# Altium schematic sheet AFE_mezzanine.SchDoc
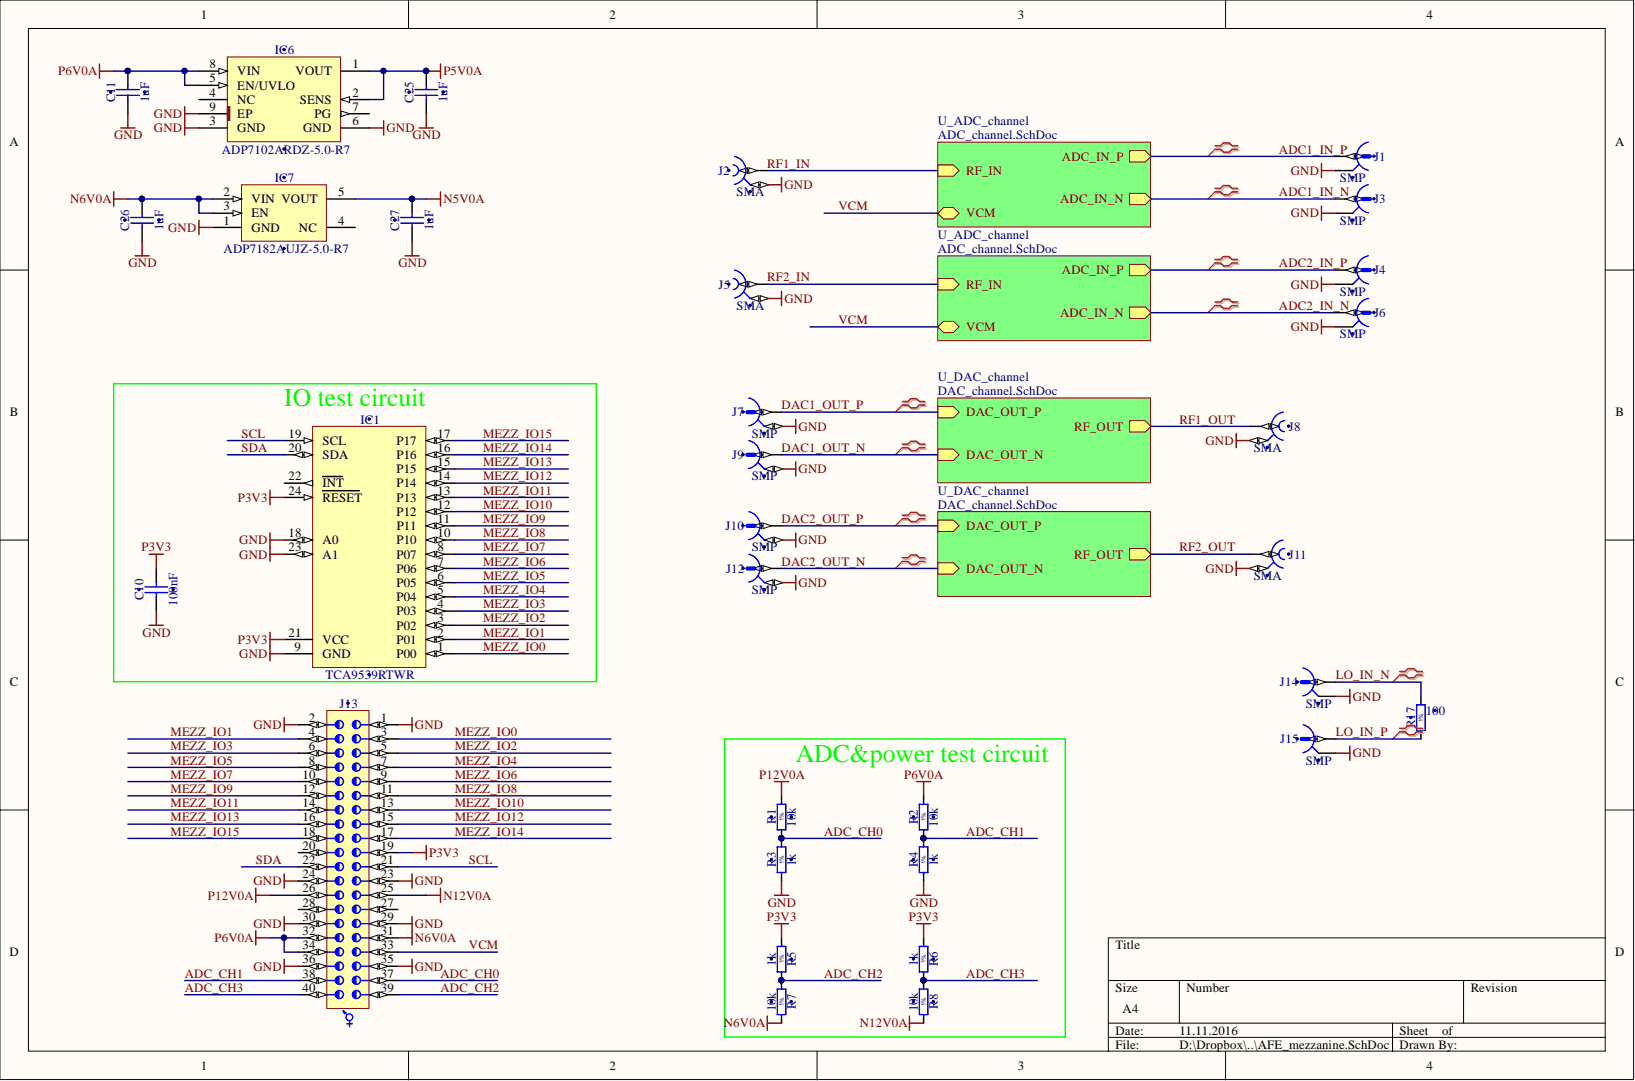
[diagram p0: AFE_mezzanine.SchDoc]

## Parts
C10  100nF  [CAPC1005X55N]
C11  1uF  [CAPC2012X140N]
C25  1uF  [CAPC2012X140N]
C26  1uF  [CAPC2012X140N]
C27  1uF  [CAPC2012X140N]
IC1  TCA9539RTWR  [QFN50P400X400X80-25N-S255]
IC6  ADP7102ARDZ-5.0-R7  [SOIC127P600X175-9N-R241X309]
IC7  ADP7182AUJZ-5.0-R7  [SOT95P280X90-5N]
J1  SMP  [AMPHENOL_SMP-MSLD-PCT-10]
J2  SMA  [Telegartner_J01151A0921]
J3  SMP  [AMPHENOL_SMP-MSLD-PCT-10]
J4  SMP  [AMPHENOL_SMP-MSLD-PCT-10]
J5  SMA  [Telegartner_J01151A0921]
J6  SMP  [AMPHENOL_SMP-MSLD-PCT-10]
J7  SMP  [MOLEX_85305-0232]
J8  SMA  [TELEGARTNER_J01151A0451]
J9  SMP  [MOLEX_85305-0232]
J10  SMP  [MOLEX_85305-0232]
J11  SMA  [TELEGARTNER_J01151A0451]
J12  SMP  [MOLEX_85305-0232]
J13  Familly  [SAMTEC_LSS-120-03-L-DV-A]  (value not drawn)
J14  SMP  [AMPHENOL_SMP-MSLD-PCT-10]
J15  SMP  [AMPHENOL_SMP-MSLD-PCT-10]
R1  10k  [RESC1005X40N]
R2  10k  [RESC1005X40N]
R3  1k  [RESC1005X40N]
R4  1k  [RESC1005X40N]
R5  1k  [RESC1005X40N]
R6  1k  [RESC1005X40N]
R7  10k  [RESC1005X40N]
R8  10k  [RESC1005X40N]
R17  100  [RESC1005X40N]

## Nets
GND: C10.1 C11.1 C25.1 C26.1 C27.1 IC1.9(GND) IC1.18(A0) IC1.23(A1) IC6.3(GND) IC6.6(GND) IC6.9(EP) IC7.1(GND) J1.2 J2.2 J3.2 J4.2 J5.2 J6.2 J7.2 J8.2 J9.2 J10.2 J11.2 J12.2 J13.1 J13.2 J13.23 J13.24 J13.29 J13.30 J13.35 J13.36 J14.2 J15.2 R3.1 R4.1
N5V0A: C27.2 IC7.5(VOUT)
N6V0A: C26.2 IC7.2(VIN) IC7.3(EN) J13.31 R7.2
N12V0A: J13.25 R8.2
P3V3: C10.2 IC1.21(VCC) IC1.24(RESET) J13.19 R5.1 R6.1
P5V0A: C25.2 IC6.1(VOUT) IC6.2(SENS)
P6V0A: C11.2 IC6.5(EN/UVLO) IC6.8(VIN) J13.32 J13.34 R2.2
P12V0A: J13.26 R1.2
ADC1_IN_N: J3.1
ADC1_IN_P: J1.1
ADC2_IN_N: J6.1
ADC2_IN_P: J4.1
ADC_CH0: J13.37 R1.1 R3.2
ADC_CH1: J13.38 R2.1 R4.2
ADC_CH2: J13.39 R5.2 R7.1
ADC_CH3: J13.40 R6.2 R8.1
DAC1_OUT_N: J9.1
DAC1_OUT_P: J7.1
DAC2_OUT_N: J12.1
DAC2_OUT_P: J10.1
LO_IN_N: J14.1 R17.2
LO_IN_P: J15.1 R17.1
MEZZ_IO0: IC1.1(P00) J13.3
MEZZ_IO1: IC1.2(P01) J13.4
MEZZ_IO2: IC1.3(P02) J13.5
MEZZ_IO3: IC1.4(P03) J13.6
MEZZ_IO4: IC1.5(P04) J13.7
MEZZ_IO5: IC1.6(P05) J13.8
MEZZ_IO6: IC1.7(P06) J13.9
MEZZ_IO7: IC1.8(P07) J13.10
MEZZ_IO8: IC1.10(P10) J13.11
MEZZ_IO9: IC1.11(P11) J13.12
MEZZ_IO10: IC1.12(P12) J13.13
MEZZ_IO11: IC1.13(P13) J13.14
MEZZ_IO12: IC1.14(P14) J13.15
MEZZ_IO13: IC1.15(P15) J13.16
MEZZ_IO14: IC1.16(P16) J13.17
MEZZ_IO15: IC1.17(P17) J13.18
RF1_IN: J2.1
RF1_OUT: J8.1
RF2_IN: J5.1
RF2_OUT: J11.1
SCL: IC1.19(SCL) J13.21
SDA: IC1.20(SDA) J13.22
VCM: J13.33
NetIC1_22: IC1.22(INT)
NetIC6_4: IC6.4(NC)
NetIC6_7: IC6.7(PG)
NetIC7_4: IC7.4(NC)
NetJ13_20: J13.20
NetJ13_27: J13.27
NetJ13_28: J13.28

## Library data of the parts
C10 = CC0402_100NF_16V_10%_X5R: Non-polarised capacitor; Manufacturer: GENERIC; Manufacturer1 Part Number: GRM155R61C104KA88D; Part Description: SMD Multilayer Chip Ceramic Capacitor; Database Table Name: Capacitors SMD; TC: X5R; Tolerance: ±10%; Voltage: 16V; Case: 0402; Component Kind: Standard; Component Type: Standard; CreateDate: 10.12.2013; HelpURL: \\cern.ch\dfs\Applications\Altium\Datasheets\CC0402_X5R_MURATA_GRM.pdf; LatestRevisionDate: 10.12.2013; Library Name: Capacitors.DbLib; Manufacturer1 ComponentHeight: 0.55mm; Manufacturer1 Example: MURATA; Manufacturer Part Number: CC0402_100NF_16V_10%_X5R; Mounted: Yes; Part Number: CC0402_100NF_16V_10%_X5R; Pin Count: 2; Sense: No; SMD: Yes; Socket: No; Status: None; Value: 100nF; Author: CERN DEM JLC
C11 C25-C27 = CC0805_1UF_35V_20%_X7R: Non-polarised capacitor; Manufacturer: GENERIC; Manufacturer1 Part Number: GMK212B7105MG-T; Part Description: SMD Multilayer Chip Ceramic Capacitor; Database Table Name: Capacitors SMD; TC: X7R; Tolerance: ±20%; Voltage: 35V; Case: 0805; Component Kind: Standard; Component Type: Standard; CreateDate: 22.06.2011; HelpURL: \\cern.ch\dfs\Applications\Altium\Datasheets\CC0805_X7R_TAIYO-YUDEN_HIGH-VALUE.pdf; LatestRevisionDate: 22.06.2011; Library Name: Capacitors.DbLib; Manufacturer1 ComponentHeight: 1.35mm; Manufacturer1 Example: TAIYO YUDEN; Manufacturer Part Number: CC0805_1UF_35V_20%_X7R; Mounted: Yes; Part Number: CC0805_1UF_35V_20%_X7R; Pin Count: 2; Sense: No; SMD: Yes; Socket: No; Status: Not Recommended; Value: 1uF; Author: CERN DEM JLC
IC1 = TCA9539RTWR: Low-Voltage 16-Bit I2C and SMBus I/O Expander; Manufacturer: TEXAS INSTRUMENTS; Part Description: Low Voltage 16-Bit I2C and SMBus Low-Power I/O Expander With Interrupt Output, Reset Pin, Configuration Registers; Bonding: No; Database Table Name: Analog & Interface; PressFit: No; Case: QFN24; ComponentHeight: 0.8mm; Component Kind: Standard; Component Type: Standard; CreateDate: 01.12.2015; Device: TCA9539RTWR; HelpURL: \\cern.ch\dfs\Applications\Altium\Datasheets\TCA9539RTWR.pdf; LatestRevisionDate: 01.12.2015; Library Name: ICs And Semiconductors.DbLib; Manufacturer Part Number: TCA9539RTWR; Mounted: Yes; PackageDescription: QFN, 0.50mm Pitch, Square; 24 Pin, 4.00mm X 4.00mm X 0.80mm H Body (w/Thermal Tab 2.55 X 2.55 mm), IPC Medium Density; Part Number: TCA9539RTWR; Pin Count: 25; Sense: No; SMD: Yes; Socket: No; Author: CERN DEM MR
IC6 = ADP7102ARDZ-5.0-R7: 300 mA, Low Noise, CMOS LDO Linear Regulator; Manufacturer: ANALOG DEVICES; Part Description: 5V, 300mA, Low Noise, CMOS LDO Linear Regulator; Bonding: No; Database Table Name: Regulators; PressFit: No; Case: SOIC8; ComponentHeight: 1.75mm; Component Kind: Standard; Component Type: Standard; CreateDate: 17.03.2014; Device: ADP7102ARDZ-5.0-R7; HelpURL: \\cern.ch\dfs\Applications\Altium\Datasheets\ADP7102ARDZ-5.0-R7.pdf; LatestRevisionDate: 17.03.2014; Library Name: ICs And Semiconductors.DbLib; Manufacturer Part Number: ADP7102ARDZ-5.0-R7; Mounted: Yes; PackageDescription: SOIC 8, Pitch 1.27mm - Body 3.9x4.9mm, (w/thermal tab 2.41mm X 3.098mm), IPC Medium Density; Part Number: ADP7102ARDZ-5.0-R7; Pin Count: 8; Sense: No; SMD: Yes; Socket: No; Author: CERN DEM JLC
IC7 = ADP7182AUJZ-5.0-R7: –5V, 200 mA, Low Noise, Linear Regulator; Manufacturer: ANALOG DEVICES; Part Description: –5V, -200mA, Low Noise, Linear Regulator; Bonding: No; Database Table Name: Regulators; PressFit: No; Case: SOT23-5; ComponentHeight: 0.9mm; Component Kind: Standard; Component Type: Standard; CreateDate: 17.03.2014; Device: ADP7182AUJZ-5.0-R7; HelpURL: \\cern.ch\dfs\Applications\Altium\Datasheets\ADP7182AUJZ-5.0-R7.pdf; LatestRevisionDate: 17.03.2014; Library Name: ICs And Semiconductors.DbLib; Manufacturer Part Number: ADP7182AUJZ-5.0-R7; Mounted: Yes; PackageDescription: SOT23, 0.95mm pitch; 5 pin, 1.75mm W X 3.00mm L, IPC Medium Density; Part Number: ADP7182AUJZ-5.0-R7; Pin Count: 5; Sense: No; SMD: Yes; Socket: No; Author: CERN DEM JLC
J1 J3 J4 J6 J14 J15 = (no symbol name): 50 Ohms, SMP Surface/THT Mount Plug, Limited Detent; Manufacturer: AMPHENOL; Manufacturer Part Number: SMP-MSLD-PCT-10; Part Description: 50 Ohms, SMP Surface Mount Plug, Limited Detent; PressFit: No; ComponentHeight: 4.1mm; Component Kind: Standard; Component Type: Standard; CreateDate: 11.11.2016; Family: SMP; HelpURL: \\cern.ch\dfs\Applications\Altium\Datasheets\MOLEX_85305-0232.pdf; LatestRevisionDate: 11.11.2016; Library Name: LIBRARY_SCH.SCHLIB; Mounted: Yes; Part Number: AMPHENOL_SMP-MSLD-PCT-10; Pin Count: 2; Sense: No; SMD: No; Socket: No; Status: None; Author: GK
J2 J5 = (no symbol name): RiSMA 50 Ohms Right Angle PCB Jack; Manufacturer: Telegartner; Manufacturer Part Number: J01151A0921; PressFit: No; ComponentHeight: 9.6mm; Component Kind: Standard; Component Type: Standard; CreateDate: 11.11.2016; Family: SMA; HelpURL: \\cern.ch\dfs\Applications\Altium\Datasheets\HUBER-SUHNER_85_SMA-50-0-101-111_NE.pdf; LatestRevisionDate: 11.11.2016; Library Name: LIBRARY_SCH.SCHLIB; Mounted: Yes; Part Description: SMA 50 Ohms Right Angle PCB Jack; Part Number: Telegartner_J01151A0921; Pin Count: 2; Sense: No; SMD: No; Socket: No; Status: None; Author: GK
J7 J9 J10 J12 = MOLEX_85305-0232: Part Description: 50 Ohms, SMP Surface Mount Plug, Limited Detent; Database Table Name: MOLEX; PressFit: No; ComponentHeight: 4.1mm; Component Kind: Standard; Component Type: Standard; CreateDate: 09.08.2016; Family: SMP; HelpURL: \\cern.ch\dfs\Applications\Altium\Datasheets\MOLEX_85305-0232.pdf; LatestRevisionDate: 09.08.2016; Library Name: Connectors.DbLib; Manufacturer: MOLEX; Manufacturer Part Number: 85305-0232; Mounted: Yes; Part Number: MOLEX_85305-0232; Pin Count: 2; Sense: No; SMD: Yes; Socket: No; Status: None; Author: CERN DEM JMW
J8 J11 = TELEGARTNER_J01151A0451: Part Description: SMA 50 ohms Edge Mount Bulkhead Jack Receptacle; Database Table Name: Miscellaneous; PressFit: No; ComponentHeight: 7mm; Component Kind: Standard; Component Type: Standard; CreateDate: 27.10.2016; Family: SMA; HelpURL: \\cern.ch\dfs\Applications\Altium\Datasheets\TELEGARTNER_J01151A0451.pdf; LatestRevisionDate: 27.10.2016; Library Name: Connectors.DbLib; Manufacturer: TELEGARTNER; Manufacturer Part Number: J01151A0451; Mounted: Yes; Part Number: TELEGARTNER_J01151A0451; Pin Count: 2; Sense: No; SMD: No; Socket: No; Status: None; Author: CERN DEM JLC
J13 = SAMTEC_LSS-120-03-L-DV-A: Connector 40 Hermaphroditic; Part Description: 40 Contacts, Pitch 0.635mm, Razor Beam LSS Vertical High Speed Hermaphroditic Strip (Lead Style 5.49mm); Database Table Name: SAMTEC; PressFit: No; ComponentHeight: 5.57mm; Component Kind: Standard; Component Type: Standard; CreateDate: 10.11.2015; HelpURL: \\cern.ch\dfs\Applications\Altium\Datasheets\SAMTEC_LSS-120-03-L-DV-A.pdf; LatestRevisionDate: 10.11.2015; Library Name: Connectors.DbLib; Manufacturer: SAMTEC; Manufacturer Part Number: LSS-120-03-L-DV-A; Mounted: Yes; Part Number: SAMTEC_LSS-120-03-L-DV-A; Pin Count: 40; Sense: No; SMD: Yes; Socket: No; Status: None; Author: CERN DEM JMW
R1 R2 R7 R8 = R0402_10K_1%_0.0625W_100PPM: Resistor - 1%; Manufacturer: GENERIC; Manufacturer1 Part Number: 232270671003L; Part Description: General Purpose Thick Film Chip Resistor; Database Table Name: Resistors SMD; TC: ±100ppm/°C; Case: 0402; Component Kind: Standard; Component Type: Standard; CreateDate: 03.12.2007; HelpURL: \\cern.ch\dfs\Applications\Altium\Datasheets\R0402_Phycomp_RC0402.pdf; LatestRevisionDate: 17.10.2012; Library Name: Resistors.DbLib; Manufacturer1 ComponentHeight: 0.4mm; Manufacturer1 Example: YAGEO PHYCOMP; Manufacturer Part Number: R0402_10K_1%_0.0625W_100PPM; Mounted: Yes; Part Number: R0402_10K_1%_0.0625W_100PPM; Pin Count: 2; Power: 0.0625W; Sense: No; SMD: Yes; Socket: No; Status: Not recommended; Tolerance: ±1%; Value: 10k; Author: CERN DEM JLC
R3-R6 = R0402_1K_1%_0.0625W_100PPM: Resistor - 1%; Manufacturer: GENERIC; Manufacturer1 Part Number: 232270671002L; Part Description: General Purpose Thick Film Chip Resistor; Database Table Name: Resistors SMD; TC: ±100ppm/°C; Case: 0402; Component Kind: Standard; Component Type: Standard; CreateDate: 03.12.2007; HelpURL: \\cern.ch\dfs\Applications\Altium\Datasheets\R0402_Phycomp_RC0402.pdf; LatestRevisionDate: 17.10.2012; Library Name: Resistors.DbLib; Manufacturer1 ComponentHeight: 0.4mm; Manufacturer1 Example: YAGEO PHYCOMP; Manufacturer Part Number: R0402_1K_1%_0.0625W_100PPM; Mounted: Yes; Part Number: R0402_1K_1%_0.0625W_100PPM; Pin Count: 2; Power: 0.0625W; Sense: No; SMD: Yes; Socket: No; Status: Not recommended; Tolerance: ±1%; Value: 1k; Author: CERN DEM JLC
R17 = R0402_100R_1%_0.0625W_100PPM: Resistor - 1%; Manufacturer: GENERIC; Manufacturer1 Part Number: 232270671001L; Part Description: General Purpose Thick Film Chip Resistor; Database Table Name: Resistors SMD; TC: ±100ppm/°C; Case: 0402; Component Kind: Standard; Component Type: Standard; CreateDate: 03.12.2007; HelpURL: \\cern.ch\dfs\Applications\Altium\Datasheets\R0402_Phycomp_RC0402.pdf; LatestRevisionDate: 17.10.2012; Library Name: Resistors.DbLib; Manufacturer1 ComponentHeight: 0.4mm; Manufacturer1 Example: YAGEO PHYCOMP; Manufacturer Part Number: R0402_100R_1%_0.0625W_100PPM; Mounted: Yes; Part Number: R0402_100R_1%_0.0625W_100PPM; Pin Count: 2; Power: 0.0625W; Sense: No; SMD: Yes; Socket: No; Status: Not recommended; Tolerance: ±1%; Value: 100; Author: CERN DEM JLC
